# Altium schematic sheet 03-Power_3v3.SchDoc
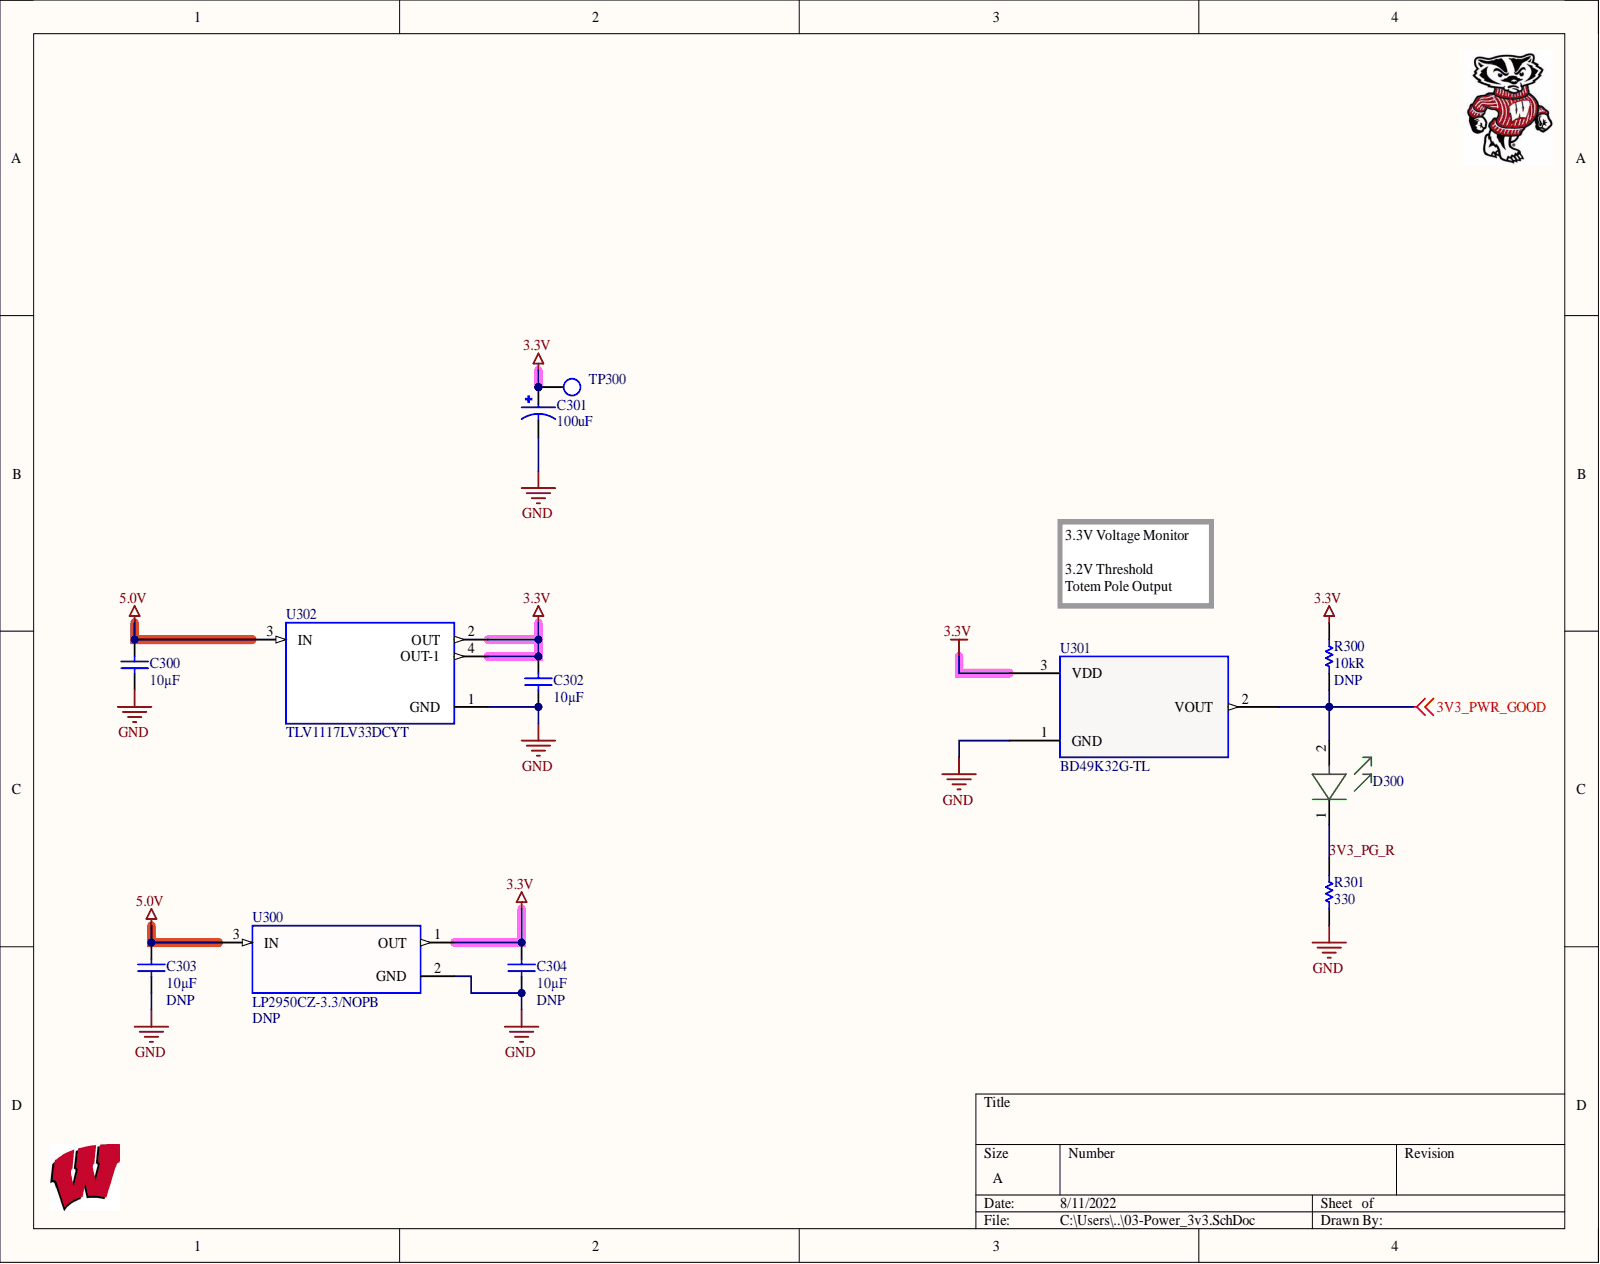
[diagram p0: 03-Power_3v3.SchDoc]

## Parts
C300  value 10µF  [CAP_0805]  (hidden comment: CAP_0805_U_010_00)
C301  Capacitance: 100uF  [CAP_EEEHA1A101WP]  (hidden comment: EEEHA1A101WP)
C302  value 10µF  [CAP_0805]  (hidden comment: CAP_0805_U_010_00)
C303  value 10µF  Usage: DNP  [CAP_0805]  (hidden comment: CAP_0805_U_010_00)  (no BOM)
C304  value 10µF  Usage: DNP  [CAP_0805]  (hidden comment: CAP_0805_U_010_00)  (no BOM)
D300  LED_GREEN_0805.1  [LED_GRN_0805]  (value not drawn)
R300  Resistance: 10kR  Usage: DNP  [RES_0805]  (hidden comment: RES_0805_K_010.00)  (no BOM)
R301  330  [RES_0805]
TP300  TP_1206  [TP-SMC-1206]  (value not drawn)
U300  LP2950CZ-3.3/NOPB  Usage: DNP  [TO92127P520H660-3]
U301  BD49K32G-TL  [ROHM-SSOP3_ROM-M]
U302  TLV1117LV33DCYT  [SOT230P700X180-4N]

## Nets
3.3V: C301.1 C302.2 C304.2 R300.2 TP300.1 U300.1 U301.3 U302.2(OUT) U302.4(OUT-1)
3V3_PWR_GOOD: D300.2 R300.1 U301.2
5.0V: C300.2 C303.2 U300.3 U302.3(IN)
GND: C300.1 C301.2 C302.1 C303.1 C304.1 R301.1 U300.2 U301.1 U302.1(GND)
3V3_PG_R: D300.1 R301.2

## Library data of the parts
C300 C302-C304 = CAP_0805_U_010_00: CAP CER 10UF 10V X5R 0805; Manufacturer: Samsung Electro-Mechanics America, Inc.; Manufacturer Part Number: CL21A106KPCLQNC; Tolerance: ±10%; Applications: General Purpose; Category: Capacitors; ComponentLink1Description: http://media.digikey.com/pdf/Data%20Sheets/Samsung%20PDFs/CL21A106KPCLQNC_character.pdf; ComponentLink1URL: http://media.digikey.com/pdf/Data%20Sheets/Samsung%20PDFs/CL21A106KPCLQNC_character.pdf; Features: -; Height - Seated (Max): -; Lead Spacing: -; Lead Style: -; Library Name: Cap_Ceramic.SchLib; Mounting Type: Surface Mount, MLCC; Operating Temperature: -55°C ~ 85°C; Package / Case: 0805 (2012 Metric); Packaging: Cut Tape (CT); Pricing 1: 1=0.2, 10=0.14, 25=0.1052, 50=0.0806, 100=0.0665, 500=0.0469, 1000=0.0385 (USD); Ratings: -; RoHS: RoHS Compliant; Size / Dimension: 0.079" L x 0.049" W (2.00mm x 1.25mm); Stock 1: 97192; Supplier 1: Digi-Key; Supplier Part Number 1: 1276-2402-1-ND; Temperature Coefficient: X5R; Thickness (Max): 0.037" (0.95mm); Voltage - Rated: 10V
  C300 C302: Component Kind: Standard
C301 = EEEHA1A101WP: Cap Aluminum 100uF 10V 20% (6.3 X 5.4mm) SMD 71mA 1000 hr 105°C T/R; Manufacturer 1: Panasonic; Manufacturer Part Number 1: EEE-HA1A101WP; Lead Diameter: 0.65mm; Leakage Current: 10uA; Life (Hours): 1000Hour; Case/Package: Radial; Category: Aluminum Electrolytic Capacitors; Component Kind: Standard; ComponentLink1Description: https://datasheet.ciiva.com/11777/0900766b8102e3f9-11777444.pdf?src-supplier=RSComponents; ComponentLink1URL: https://datasheet.ciiva.com/11777/0900766b8102e3f9-11777444.pdf?src-supplier=RSComponents; ComponentLink2Description: https://datasheet.ciiva.com/46388/1446029-46388171.pdf?src-supplier=Element14; ComponentLink2URL: https://datasheet.ciiva.com/46388/1446029-46388171.pdf?src-supplier=Element14; ComponentLink3Description: https://datasheet.ciiva.com/6134/1446029-6134159.pdf?src-supplier=Farnell; ComponentLink3URL: https://datasheet.ciiva.com/6134/1446029-6134159.pdf?src-supplier=Farnell; ComponentLink4Description: https://datasheet.ciiva.com/46376/1446029-46376829.pdf?src-supplier=Element14; ComponentLink4URL: https://datasheet.ciiva.com/46376/1446029-46376829.pdf?src-supplier=Element14; ComponentLink5Description: https://datasheet.ciiva.com/28671/1446029-28671512.pdf?src-supplier=Element14; ComponentLink5URL: https://datasheet.ciiva.com/28671/1446029-28671512.pdf?src-supplier=Element14; ComponentLink6Description: https://datasheet.ciiva.com/14594/70256712-14594504.pdf?src-supplier=Allied+Electronics; ComponentLink6URL: https://datasheet.ciiva.com/14594/70256712-14594504.pdf?src-supplier=Allied+Electronics; ComponentLink7Description: https://datasheet.ciiva.com/10331/aba0000ce3-10331085.pdf?src-supplier=Avnet; ComponentLink7URL: https://datasheet.ciiva.com/10331/aba0000ce3-10331085.pdf?src-supplier=Avnet; ComponentLink8Description: https://datasheet.ciiva.com/16288/aba0000ce3-16288621.pdf?src-supplier=Future+Electronics; ComponentLink8URL: https://datasheet.ciiva.com/16288/aba0000ce3-16288621.pdf?src-supplier=Future+Electronics; ComponentLink9Description: https://datasheet.ciiva.com/15166/getdatasheetpartid-169220-15166125.pdf?src-supplier=Verical; ComponentLink9URL: https://datasheet.ciiva.com/15166/getdatasheetpartid-169220-15166125.pdf?src-supplier=Verical; Construction: Cylindrical; Contact Plating: Tin; Diameter: 6.3mm; Height: 5.4mm; Height - Seated (Max): 0.213inch; Lead Free: Lead Free; Lead Pitch: 1.8mm; Length: 5.5mm; Library Name: Cap_Aluminum.SchLib; Max Operating Temperature: 105°C; Min Operating Temperature: -40°C; Mount: Surface Mount,PCB; Package Quantity: 1000; Packaging: Tape and Reel; Pricing 1: 1=0.52000, 10=0.36200, 50=0.25340, 100=0.21710, 500=0.16284 (USD); Radiation Hardening: No; REACH SVHC: Unknown; Ripple Current: 71mA; Ripple Current (AC): 71mA; RoHS: Yes; RoHS Compliant: Yes; Series: HA; Stock 1: 140513; Supplier 1: Digi-Key; Supplier Part Number 1: PCE4169CT-ND; Termination: SMD/SMT; Tolerance: 20%; Voltage: 10V; Voltage Rating: 10V; Voltage Rating (DC): 10V; Width: 650um
D300 = LED_GREEN_0805.1: LED GREEN CLEAR THIN 0805 SMD; Manufacturer 1: Vishay / Lite-On; Manufacturer Part Number 1: LTST-C171KGKT; Chip Material: AlGaInP; DELETED: Compliant; Dominant Wave Length: 571 nm; Emitting Color: Yellow Green; Forward Current: 30 mA; Forward Voltage: 2.4 V; High Brightness: Yes; Lum Intensity: 35mcd; Main Category: Chip LED; Number of  Elements: 1; Peak Wavelength: 574 nm; Power Dissipation: 75 W; Product Depth (mm): 1.25 mm; Product Height (mm): 0.8 mm; Product Length (mm): 2 mm; Rad Hardened: No; Reverse Voltage: 5 V; Shape Type: Rectangular; Test Current (It): 20 mA; Type: Uni-Color; Viewing Angle: 130 deg; Component Kind: Standard; ComponentLink1Description: https://datasheet.ciiva.com/15118/getdatasheetpartid-214542-15118099.pdf; ComponentLink1URL: https://datasheet.ciiva.com/15118/getdatasheetpartid-214542-15118099.pdf; ComponentLink2Description: https://datasheet.ciiva.com/16200/lite-on20ltst-c171kgkt-16200100.pdf; ComponentLink2URL: https://datasheet.ciiva.com/16200/lite-on20ltst-c171kgkt-16200100.pdf; ComponentLink3Description: https://datasheet.ciiva.com/2110/s-110-ltst-c171kgkt-2110072.pdf; ComponentLink3URL: https://datasheet.ciiva.com/2110/s-110-ltst-c171kgkt-2110072.pdf; ComponentLink4Description: https://datasheet.ciiva.com/2110/s-110-ltst-c171kgkt-2110073.pdf; ComponentLink4URL: https://datasheet.ciiva.com/2110/s-110-ltst-c171kgkt-2110073.pdf; ComponentLink5Description: https://datasheet.ciiva.com/11774/0900766b80dc0298-11774377.pdf; ComponentLink5URL: https://datasheet.ciiva.com/11774/0900766b80dc0298-11774377.pdf; ComponentLink6Description: https://datasheet.ciiva.com/2110/s-110-ltst-c171kgkt-2110074.pdf; ComponentLink6URL: https://datasheet.ciiva.com/2110/s-110-ltst-c171kgkt-2110074.pdf; ComponentLink7Description: https://datasheet.ciiva.com/12400/lite-on-ltst-c171kgkt-187692-12400799.pdf; ComponentLink7URL: https://datasheet.ciiva.com/12400/lite-on-ltst-c171kgkt-187692-12400799.pdf; Lens Dimensions: 1.4x1.25x0.5 mm; Library Name: LEDs.SchLib; Luminous Intensity: 35mcd; Mounting: Surface Mount; Operating Temp Range: -55C to 85C; Operating Temperature Classification: Industrial; Package Type: Chip Led; Packaging: Tape and Reel; Pin Count: 2; Pricing 1: 1=0.31, 10=0.225, 25=0.1656, 100=0.1183, 250=0.0804, 500=0.071, 1000=0.0556 (USD); Stock 1: 322411; Supplier 1: Digi-Key; Supplier Part Number 1: 160-1426-1-ND
R300 = RES_0805_K_010.00: RES SMD 10K OHM 1% 1/8W 0805; Manufacturer 1: Yageo; Manufacturer Part Number 1: RC0805FR-0710KL; Max Power Dissipation: 125mW; Temperature Coefficient: 100ppm/°C; Voltage Rating: 150V; Case Code (Imperial): 0805; Case Code (Metric): 2012; Case/Package: 0805; Category: Chip SMD Resistors; ComponentLink1Description: https://datasheet.ciiva.com/11808/0900766b8106beab-11808151.pdf?src-supplier=RSComponents; ComponentLink1URL: https://datasheet.ciiva.com/11808/0900766b8106beab-11808151.pdf?src-supplier=RSComponents; ComponentLink2Description: https://datasheet.ciiva.com/12669/rc0805-12669688.pdf?src-supplier=Mouser; ComponentLink2URL: https://datasheet.ciiva.com/12669/rc0805-12669688.pdf?src-supplier=Mouser; ComponentLink3Description: https://datasheet.ciiva.com/46447/444164-46447563.pdf?src-supplier=Element14; ComponentLink3URL: https://datasheet.ciiva.com/46447/444164-46447563.pdf?src-supplier=Element14; ComponentLink4Description: https://datasheet.ciiva.com/2456/pyu-r-int-thick-7-2456972.pdf?src-supplier=Digi-Key; ComponentLink4URL: https://datasheet.ciiva.com/2456/pyu-r-int-thick-7-2456972.pdf?src-supplier=Digi-Key; ComponentLink5Description: https://datasheet.ciiva.com/2456/pyu-r-int-thick-7-2456973.pdf?src-supplier=Digi-Key; ComponentLink5URL: https://datasheet.ciiva.com/2456/pyu-r-int-thick-7-2456973.pdf?src-supplier=Digi-Key; ComponentLink6Description: https://datasheet.ciiva.com/2456/pyu-r-int-thick-7-2456974.pdf?src-supplier=Digi-Key; ComponentLink6URL: https://datasheet.ciiva.com/2456/pyu-r-int-thick-7-2456974.pdf?src-supplier=Digi-Key; ComponentLink7Description: https://datasheet.ciiva.com/16747/rc0805-16747087.pdf?src-supplier=Future+Electronics; ComponentLink7URL: https://datasheet.ciiva.com/16747/rc0805-16747087.pdf?src-supplier=Future+Electronics; Construction: Rectangular; Depth: 1.25mm; Features: Moisture Resistant; Height: 0.024inch; Lead Free: Lead Free; Length: 2mm; Library Name: Resistors.SchLib; Max Operating Temperature: 155°C; Military Standard: Not; Min Operating Temperature: -55°C; Number of Terminations: 2; Package Quantity: 1; Packaging: Tape and Reel; Power Rating: 125mW; Pricing 1: 1=0.10000, 10=0.04200, 100=0.01690, 1000=0.00759, 2500=0.00659 (USD); REACH SVHC: Unknown; Resistance Tolerance: 1%; Resistor Type: Thick Film; RoHS: Yes; RoHS Compliant: Yes; Series: RC; Size Code: 0805; Stock 1: 7562122; Supplier 1: Digi-Key; Supplier Part Number 1: 311-10.0KCRCT-ND; Technology: THICK FILM; Termination: SMD/SMT; Tolerance: 1%; Width: 0.049inch
R301 = RES_0805_R_330.00: RES 330 OHM 1% 1/8W 0805; Manufacturer 1: Stackpole Electronics; Manufacturer Part Number 1: RMCF0805FT330R; Max Power Dissipation: 125mW; Resistance: 330R; Temperature Coefficient: 100ppm/°C; Case Code (Imperial): 0805; Case Code (Metric): 2012; Case/Package: 0805; Category: Chip SMD Resistors; Component Kind: Standard; ComponentLink1Description: http://components.arrow.com/part/dataview/40963487S9848862N1174?src-supplier=Arrow+Electronics; ComponentLink1URL: http://components.arrow.com/part/dataview/40963487S9848862N1174?src-supplier=Arrow+Electronics; ComponentLink2Description: https://www.seielect.com/catalog/SEI-RMCF_RMCP.pdf?src-supplier=Avnet; ComponentLink2URL: https://www.seielect.com/catalog/SEI-RMCF_RMCP.pdf?src-supplier=Avnet; ComponentLink3Description: https://www.seielect.com/Catalog/SEI-RMCF_RMCP.pdf?src-supplier=Digi-Key; ComponentLink3URL: https://www.seielect.com/Catalog/SEI-RMCF_RMCP.pdf?src-supplier=Digi-Key; ComponentLink4Description: https://www.seielect.com/catalog/SEI-Packaging.pdf?src-supplier=Digi-Key; ComponentLink4URL: https://www.seielect.com/catalog/SEI-Packaging.pdf?src-supplier=Digi-Key; ComponentLink5Description: https://www.verical.com/server-webapp/html/getDatasheet?partID=559884&src-supplier=Verical; ComponentLink5URL: https://www.verical.com/server-webapp/html/getDatasheet?partID=559884&src-supplier=Verical; Construction: Rectangular; Contact Plating: Tin,Matte; Depth: 1.25mm; Features: Automotive AEC-Q200; Height: 0.026inch; Lead Free: Lead Free; Length: 0.079inch; Library Name: Resistors.SchLib; Max Operating Temperature: 155°C; Military Standard: Not; Min Operating Temperature: -55°C; Mount: Surface Mount; Number of Terminations: 2; Packaging: Tape and Reel; Pricing 1: 1=0.10000, 10=0.02700, 100=0.01090, 1000=0.00488, 2500=0.00423 (USD); Radiation Hardening: No; Resistor Type: Thick Film; RoHS: Yes; RoHS Compliant: Yes; Series: RMCF; Stock 1: 205996; Supplier 1: Digi-Key; Supplier Part Number 1: RMCF0805FT330RCT-ND; Tolerance: 1%; Width: 0.049inch
TP300 = TP_1206: Test Point SMD 1206; Manufacturer: TE Connectivity (Tyco Electronics); Manufacturer Part Number: 1625854-1; Power Rating: N/R; Resistance Value: 0 Ohm; Case Size: 1206; Component Kind: Standard; ComponentLink1Description: https://datasheet.ciiva.com/6439/eng-ds-1773266-a-6439169.pdf; ComponentLink1URL: https://datasheet.ciiva.com/6439/eng-ds-1773266-a-6439169.pdf; ComponentLink2Description: https://datasheet.ciiva.com/30136/1773266-a-30136341.pdf; ComponentLink2URL: https://datasheet.ciiva.com/30136/1773266-a-30136341.pdf; ComponentLink3Description: https://datasheet.ciiva.com/6439/eng-ds-1773266-a-6439080.pdf; ComponentLink3URL: https://datasheet.ciiva.com/6439/eng-ds-1773266-a-6439080.pdf; ComponentLink4Description: https://datasheet.ciiva.com/6439/eng-ds-1773266-a-6439081.pdf; ComponentLink4URL: https://datasheet.ciiva.com/6439/eng-ds-1773266-a-6439081.pdf; ComponentLink5Description: https://datasheet.ciiva.com/6439/eng-ds-1773266-a-6439083.pdf; ComponentLink5URL: https://datasheet.ciiva.com/6439/eng-ds-1773266-a-6439083.pdf; Dimension: 3.2 x 1.6 x 1.25mm; Family: RC; Library Name: Mechanical.SchLib; Mounting: Surface Mount; Operating Temperature: -55 to 125 C; Packaging: Tape and Reel; Part No: 1625854-1; Pricing 1: 1=0.28, 10=0.261, 25=0.224, 50=0.1902, 100=0.1828, 250=0.1641, 500=0.1567, 1000=0.1306 (USD); RoHS: Yes; Stock 1: 31937; Supplier: Digi-Key; Supplier Part Number: A106143CT-ND; Temperature Coefficient: N/R; Terminal Pitch: N/R; Termination Style: N/R; Tolerance: N/R
U300 = LP2950CZ-3.3/NOPB: LDO Regulator Pos 3.3V 0.1A 3-Pin TO-92 Bulk / IC REG LDO 3.3V 0.1A TO92-3; Manufacturer 1: TI National Semiconductor; Accuracy: 0.5%; Dropout Voltage: 380mV; Max Input Voltage: 30V; Max Output Current: 100mA; MF: Texas Instruments; Number of Outputs: 1; Output Type: Fixed; Polarity: Positive; Quiescent Current: 75uA; Availability: In Stock; Case/Package: TO-92; Category: Voltage Regulators - Linear; Check_prices: https://www.snapeda.com/parts/LP2950CZ-3.3/NOPB/Texas+Instruments/view-part/?ref=eda; Component Kind: Standard; ComponentLink1Description: https://datasheet.ciiva.com/41458/001014275-da-01-en-ic-reg-ldo-lp2950cz-3-3-nopb-to-92-3-tid-41458656.pdf?src-supplier=Conrad; ComponentLink1URL: https://datasheet.ciiva.com/41458/001014275-da-01-en-ic-reg-ldo-lp2950cz-3-3-nopb-to-92-3-tid-41458656.pdf?src-supplier=Conrad; ComponentLink2Description: https://datasheet.ciiva.com/1511/1902686-1511857.pdf?src-supplier=Element14; ComponentLink2URL: https://datasheet.ciiva.com/1511/1902686-1511857.pdf?src-supplier=Element14; ComponentLink3Description: https://datasheet.ciiva.com/2047/lp2950-n-2047542.pdf?src-supplier=Digi-Key; ComponentLink3URL: https://datasheet.ciiva.com/2047/lp2950-n-2047542.pdf?src-supplier=Digi-Key; Contact Plating: Copper,Tin; Height: 5.2mm; Lead Free: Lead Free; Length: 5.2mm; Library Name: Voltage_Regulators.SchLib; Manufacturer Part Number 1: LP2950CZ-3.3/NOPB; Max Operating Temperature: 125°C; Min Operating Temperature: -40°C; Mount: Through Hole; MP: LP2950CZ-3.3/NOPB; Nominal Output Voltage: 3.3V; Number of Pins: 3; Output Current: 100mA; Output Voltage: 3.3V; Output Voltage Accuracy: 0.5%; Package: TO-92-3 Texas Instruments; Package Quantity: 1800; Packaging: Box; Price: None; Pricing 1: 1=1.15000, 10=1.03200, 25=0.97960, 80=0.80450, 230=0.75209, 440=0.66461, 1800=0.57750 (USD); Purchase-URL: https://pricing.snapeda.com/search/part/LP2950CZ-3.3/NOPB/?ref=eda; Radiation Hardening: No; REACH SVHC: No SVHC; RoHS: Yes; RoHS Compliant: Yes; SnapEDA_Link: https://www.snapeda.com/parts/LP2950CZ-3.3/NOPB/Texas+Instruments/view-part/?ref=snap; Stock 1: 3132; Supplier 1: Digi-Key; Supplier Part Number 1: LP2950CZ-3.3/NOPB-ND; Weight: 0.01lb; Width: 4.19mm
U301 = BD49K32G-TL: Processor Supervisor 3.2V 1 Active Low/CMOS 3-Pin SSOP T/R; Manufacturer 1: Rohm; Number of Voltages Monitored: 1; Case/Package: TO-236-3; Category: Voltage Supervisors; Component Kind: Standard; ComponentLink1Description: https://www.rohm.com/datasheet/BD48K32G/bd48xxg-e?src-supplier=Digi-Key; ComponentLink1URL: https://www.rohm.com/datasheet/BD48K32G/bd48xxg-e?src-supplier=Digi-Key; Lead Free: Lead Free; Library Name: ICs.SchLib; Manufacturer Part Number 1: BD49K32G-TL; Max Operating Temperature: 105°C; Min Operating Temperature: -40°C; Mount: Surface Mount; Packaging: Cut Tape; Pricing 1: 1=0.37000, 10=0.29900, 25=0.27360, 100=0.20350, 250=0.18444, 500=0.15264, 1000=0.11448 (USD); Pricing 2: 1=0.37000, 10=0.29900, 25=0.27360, 100=0.20350, 250=0.18444, 500=0.15264, 1000=0.11448 (USD); Reset: Active Low; RoHS: Yes; RoHS Compliant: Yes; Stock 1: 4461; Stock 2: 4461; Supplier 1: Digi-Key; Supplier Part Number 1: BD49K32G-TLCT-ND; Threshold Voltage: 3.2V; Type: Voltage Detector
U302 = TLV1117LV33DCYT: TLV1117LV 1-A, Positive Fixed Vo; Manufacturer 1: Texas Instruments; Accuracy: 2%; Dropout Voltage: 455mV; Max Input Voltage: 5.5V; Max Output Current: 1A; Max Output Voltage: 3.3V; Max Power Dissipation: 1.59W; Min Current Limit: 1.1A; Min Input Voltage: 2V; Number of Outputs: 1; Output Voltage Accuracy: 1.5%; Quiescent Current: 50uA; Case/Package: SOT-223; Category: Voltage Regulators - Linear; Component Kind: Standard; ComponentLink1Description: http://www.ti.com/general/docs/lit/getliterature.tsp?genericPartNumber=TLV1117LV&&fileType=pdf&src-supplier=Farnell; ComponentLink1URL: http://www.ti.com/general/docs/lit/getliterature.tsp?genericPartNumber=TLV1117LV&&fileType=pdf&src-supplier=Farnell; ComponentLink2Description: http://www.farnell.com/datasheets/1950591.pdf?src-supplier=Element14; ComponentLink2URL: http://www.farnell.com/datasheets/1950591.pdf?src-supplier=Element14; ComponentLink3Description: http://www.ti.com/lit/ds/symlink/tlv1117lv.pdf?src-supplier=Digi-Key; ComponentLink3URL: http://www.ti.com/lit/ds/symlink/tlv1117lv.pdf?src-supplier=Digi-Key; ComponentLink4Description: http://www.ti.com/general/docs/suppproductinfo.tsp?distId=10&amp;gotoUrl=http%3A%2F%2Fwww.ti.com%2Flit%2Fgpn%2Ftlv1117lv&src-supplier=Digi-Key; ComponentLink4URL: http://www.ti.com/general/docs/suppproductinfo.tsp?distId=10&amp;gotoUrl=http%3A%2F%2Fwww.ti.com%2Flit%2Fgpn%2Ftlv1117lv&src-supplier=Digi-Key; ComponentLink5Description: http://www.ti.com/lit/gpn/tlv1117lv?src-supplier=Mouser; ComponentLink5URL: http://www.ti.com/lit/gpn/tlv1117lv?src-supplier=Mouser; ComponentLink6Description: http://www.ti.com/general/docs/lit/getliterature.tsp?genericPartNumber=TLV1117LV&&fileType=pdf&src-supplier=Avnet; ComponentLink6URL: http://www.ti.com/general/docs/lit/getliterature.tsp?genericPartNumber=TLV1117LV&&fileType=pdf&src-supplier=Avnet; ComponentLink7Description: http://components.arrow.com/part/dataview/51117919S8954709N7713?src-supplier=Arrow+Electronics; ComponentLink7URL: http://components.arrow.com/part/dataview/51117919S8954709N7713?src-supplier=Arrow+Electronics; Contact Plating: Tin; Lead Free: Lead Free; Library Name: Voltage_Regulators.SchLib; Manufacturer Part Number 1: TLV1117LV33DCYT; Max Operating Temperature: 125°C; Min Operating Temperature: -40°C; Min Output Voltage: 3.3V; Nominal Output Voltage: 3.3V; Number of Pins: 4; Number of Regulators: 1; Output Current: 1A; Output Type: Fixed; Output Voltage: 3.3V; Package Quantity: 250; Packaging: Tape and Reel; Polarity: Positive; Power Dissipation: 1.59W; Pricing 1: 1=1.15000, 10=1.02600, 25=0.97360, 100=0.79970 (USD); Radiation Hardening: No; REACH SVHC: No SVHC; RoHS: Yes; RoHS Compliant: Yes; Supplier 1: Digi-Key; Supplier Part Number 1: 296-35976-1-ND
